# Altium schematic sheet gosterge.SchDoc
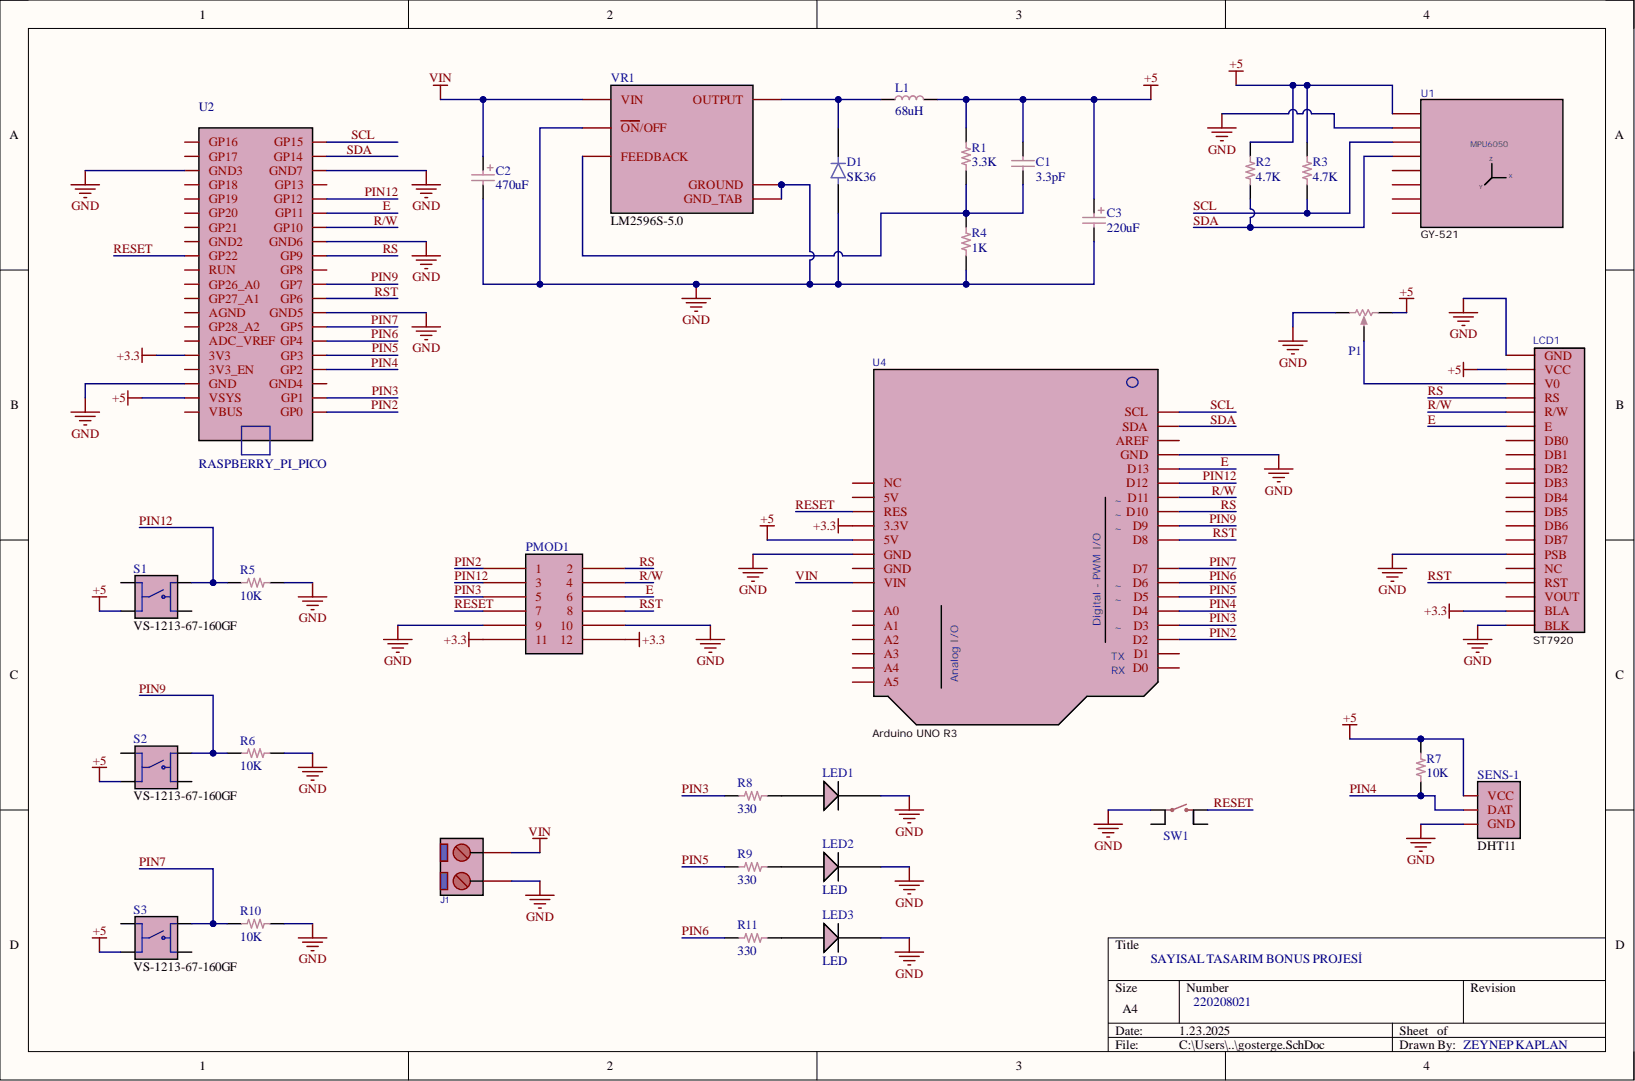
[diagram p0: gosterge.SchDoc]

## Parts
C1  3.3pF  [CAP FILM 1206]
C2  470uF  [CAP 470,220uF ALSMD ELEC 10MM H10.5MM]
C3  220uF  [CAP 470,220uF ALSMD ELEC 10MM H10.5MM]
D1  SK36  [DIOSC6959X240]
J1  Phoenix MKDS 2Pin  [TE 282834-2]  (value not drawn)
L1  68uH  [WURTH WE-PD 1260]
LCD1  ST7920  [LCD 128X64 CONTROLLER ST7920]
LED1  LED  [LED 0805]
LED2  LED  [LED 0805]
LED3  LED  [LED 0805]
P1  10K  [VOL RA]  (value not drawn)
PMOD1  Header 2x6  [HDRR_M_2X6]  (value not drawn)
R1  3.3K  [RES 0805_2012]
R2  4.7K  [RES 0805_2012]
R3  4.7K  [RES 0805_2012]
R4  1K  [RES 0805_2012]
R5  10K  [RES 0805_2012]
R6  10K  [RES 0805_2012]
R7  10K  [RES 0805_2012]
R8  330  [RES 0805_2012]
R9  330  [RES 0805_2012]
R10  10K  [RES 0805_2012]
R11  330  [RES 0805_2012]
S1  VS-1213-67-160GF  [SW_VS-1213-67-160GF]
S2  VS-1213-67-160GF  [SW_VS-1213-67-160GF]
S3  VS-1213-67-160GF  [SW_VS-1213-67-160GF]
SENS-1  DHT11  [SENSOR-DHT11]
SW1  Tactile 4PIN 6X6mm H5mm (THD)  [SWPBT_SPDT_6X6X5TS]  (value not drawn)
U1  GY-521  [GY-521]
U2  RASPBERRY_PI_PICO  [RASPBERRY_PI_PICO]
U4  Arduino UNO R3  [ARDUINO UNO R3 FOOTPRINT]
VR1  LM2596S-5.0  [TO170P1524X483-6N]

## Nets
+3.3: LCD1.19(BLA) PMOD1.11 PMOD1.12 U2.3V3 U4.4(3.3V)
+5: C1.2 C3.1 L1.2 LCD1.2(VCC) P1.3 R1.2 R2.2 R3.2 R7.1 S1.2 S2.2 S3.2 SENS-1.P$1 U1.1(Vcc) U2.VSYS U4.5(5V)
GND: C2.2 C3.2 D1.A J1.1 LCD1.1(GND) LCD1.15(PSB) LCD1.20(BLK) LED1.2 LED2.2 LED3.2 P1.1 PMOD1.9 PMOD1.10 R4.1 R5.1 R6.1 R10.1 SENS-1.P$4 SW1.1 U1.2(GND) U2.GND U2.GND3 U2.GND5 U2.GND6 U2.GND7 U4.6(GND) U4.18(GND) VR1.3(GROUND) VR1.5(ON/OFF) VR1.6(GND_TAB)
E: LCD1.6(E) PMOD1.6 U2.GP11 U4.19(D13)
PIN2: PMOD1.1 U2.GP0 U4.30(D2)
PIN3: PMOD1.5 R8.2 U2.GP1 U4.29(D3)
PIN4: R7.2 SENS-1.P$2 U2.GP2 U4.28(D4)
PIN5: R9.2 U2.GP3 U4.27(D5)
PIN6: R11.2 U2.GP4 U4.26(D6)
PIN7: R10.2 S3.3 U2.GP5 U4.25(D7)
PIN9: R6.2 S2.3 U2.GP7 U4.23(D9)
PIN12: PMOD1.3 R5.2 S1.3 U2.GP12 U4.20(D12)
R/W: LCD1.5(R/W) PMOD1.4 U2.GP10 U4.21(D11)
RESET: PMOD1.7 SW1.2 U2.GP22 U4.3(RES)
RS: LCD1.4(RS) PMOD1.2 U2.GP9 U4.22(~_D10)
RST: LCD1.17(RST) PMOD1.8 U2.GP6 U4.24(D8)
SCL: R3.1 U1.3(SCL) U2.GP15 U4.15(SCL)
SDA: R2.1 U1.4(SDA) U2.GP14 U4.16(SDA)
VIN: C2.1 J1.2 U4.8(VIN) VR1.1(VIN)
NetC1_1: C1.1 R1.1 R4.2 VR1.4(FEEDBACK)
NetD1_C: D1.C L1.1 VR1.2(OUTPUT)
NetLCD1_3: LCD1.3(V0) P1.2
NetLED1_1: LED1.1 R8.1
NetLED2_1: LED2.1 R9.1
NetLED3_1: LED3.1 R11.1

Not connected: LCD1 7(DB0) 8(DB1) 9(DB2) 10(DB3) 11(DB4) 12(DB5) 13(DB6) 14(DB7) 16(NC) 18(VOUT); S1 1 4; S2 1 4; S3 1 4; SW1 3 4; U1 5(XDA) 6(XCL) 7(AD0) 8(INT); U2 3V3_EN ADC_VREF AGND GND2 GND4 GP8 GP13 GP16 GP17 GP18 GP19 GP20 GP21 GP26_A0 GP27_A1 GP28_A2 RUN VBUS; U4 1(NC) 2(5V) 7(GND) 9(A0) 10(A1) 11(A2) 12(A3) 13(A4) 14(A5) 17(AREF) 31(D1) 32(D0)

## Library data of the parts
C1 = Cap: Capacitor; Component Kind: Standard; LatestRevisionDate: 17-Jul-2002; LatestRevisionNote: Re-released for DXP Platform.; Library Name: Schlib.SchLib; PackageDescription: Radial Cap, Thru-Hole; 2 Leads; 0.3 in Pin Spacing; PackageReference: RAD-0.3; Published: 8-Jun-2000; Publisher: Altium Limited
C2 C3 = Cap Pol: Polarized Capacitor (Surface Mount); Component Kind: Standard; LatestRevisionDate: 29-May-2009; LatestRevisionNote: IPC-7351 Footprint Added.; Library Name: Schlib.SchLib; PackageDescription: Chip Capacitor; PackageReference: C0805; Published: 8-Jun-2000; Publisher: Altium Limited
D1 = SK36: Diode Schottky 60V 3A Surface Mount DO-214AC (SMA); MF: Micro Commercial; Availability: Bad; Component Kind: Standard; Library Name: Schlib.SchLib; MP: SK36; Package: DO-214 Diotec; Price: None; SnapEDA_Link: https://www.snapeda.com/parts/SK36/Micro%20Commercial/view-part/455175/?ref=snap
J1 = Phoenix MKDS 2Pin: CONN, PHOENIX MKDS 5.08mm pitch; PartNumber: MKDS 1,5/ 2-5,08 - 1715721; Component Kind: Standard; Library Name: Schlib.SchLib
L1 = Bobin126068uH: SMT Inductor; Component Kind: Standard; Library Name: Schlib.SchLib
P1 = Vol RA: Component Kind: Standard; Library Name: Schlib.SchLib; VALUE: 10K
R1-R3 R5-R11 = Res 0805: SMT Resistor; VALUE: 1K; Component Kind: Standard; Library Name: Schlib.SchLib
R4 = Res 0805: SMT Resistor; Component Kind: Standard; Library Name: Schlib.SchLib; VALUE: 1K
S1-S3 = VS-1213-67-160GF: Flat Type PCB Mount 12 x 12mm Tact Switches; Availability: Unavailable; Component Kind: Standard; Library Name: Schlib.SchLib; MP: VS-1213-67-160GF; Package: None; Price: None
SENS-1 = DHT11: Humidity, Temperature Digital Â±5% RH Through Hole; MF: UNIVERSAL-SOLDER Electronics Ltd; Check_prices: https://www.snapeda.com/parts/DHT11/Ersa/view-part/?ref=eda; Component Kind: Standard; Library Name: Schlib.SchLib; MP: DHT11; Package: None; SnapEDA_Link: https://www.snapeda.com/parts/DHT11/Ersa/view-part/?ref=snap
SW1 = Tactile 4PIN 6X6mm H5mm (THD): Tactile Switch SPST-NO Top Actuated Surface Mount; PartNumber: PTS645SH50SMTR92 LFS; Component Kind: Standard; Library Name: Schlib.SchLib
U2 = RASPBERRY_PI_PICO: Raspberry Pi Board, Arm Cortex-M0+; Core Architecture:Arm; Core Sub-Architecture:Cortex-M0+; Kit Contents:Raspberry Pi Pico Board; No. Of Bits:32Bit; Silicon Core Number:Rp2040; Silicon Manufacturer:Raspberry Pi |Raspberry-Pi RASPBERRY PI PICO; Check_prices: https://www.snapeda.com/parts/RASPBERRY%20PI%20PICO/Raspberry+Pi/view-part/?ref=eda; Component Kind: Standard; Library Name: Schlib.SchLib; MF: Raspberry Pi; MP: RASPBERRY PI PICO; Package: None; SnapEDA_Link: https://www.snapeda.com/parts/RASPBERRY%20PI%20PICO/Raspberry+Pi/view-part/?ref=snap
VR1 = LM2596S-5.0: SIMPLE SWITCHER&reg; 4.5V to 40V, 3A Low Component Count Step-Down Regulator; MF: Texas Instruments; MP: LM2596S-5.0/NOPB; Availability: In Stock; Check_prices: https://www.snapeda.com/parts/LM2596S-5.0/NOPB/Texas+Instruments/view-part/?ref=eda; Component Kind: Standard; Library Name: Schlib.SchLib; Package: TO-263-5 Texas Instruments; Price: None; Purchase-URL: https://pricing.snapeda.com/search/part/LM2596S-5.0/NOPB/?ref=eda; SnapEDA_Link: https://www.snapeda.com/parts/LM2596S-5.0/NOPB/Texas+Instruments/view-part/?ref=snap
LCD1 = ST7920: Component Kind: Standard; Library Name: Schlib.SchLib
LED1-LED3 = LED: Component Kind: Standard; Library Name: Schlib.SchLib
PMOD1 = Header 2x6: Component Kind: Standard; Library Name: Schlib.SchLib
U1 = GY-521: Component Kind: Standard; Library Name: Schlib.SchLib
U4 = Arduino UNO R3: Component Kind: Standard; Library Name: Schlib.SchLib
